# Altium schematic sheet Power.SchDoc(Power)
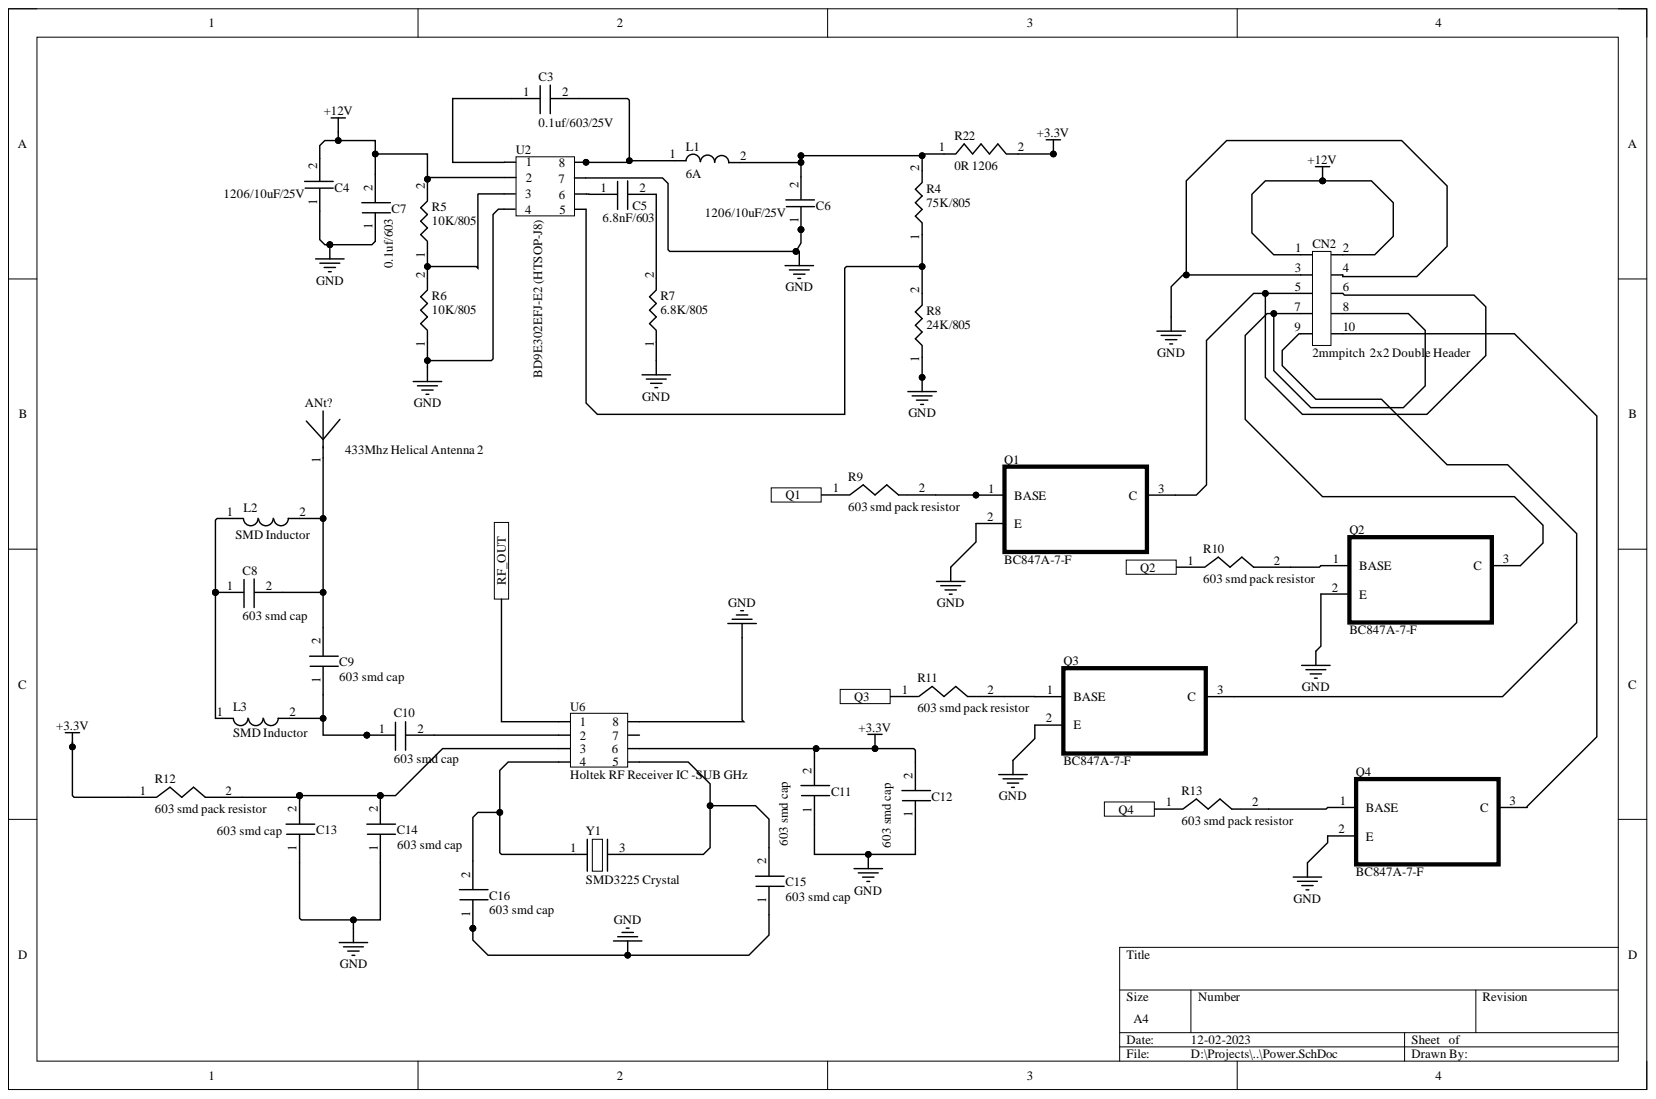
[diagram p0: Power.SchDoc]

## Parts
ANt?  433Mhz Helical Antenna 2  [433Mhz Antenna 2]
C3  0.1uf/603/25V  [603]
C4  1206/10uF/25V  [1206]
C5  6.8nF/603  [603]
C6  1206/10uF/25V  [1206]
C7  0.1uf/603  [603]
C8  603 smd cap  [603]
C9  603 smd cap  [603]
C10  603 smd cap  [603]
C11  603 smd cap  [603]
C12  603 smd cap  [603]
C13  603 smd cap  [603]
C14  603 smd cap  [603]
C15  603 smd cap  [603]
C16  603 smd cap  [603]
CN2  2mmpitch  2x2 Double Header  [DS1026-05]
L1  6A  [SMD Power Inductor PAD_1]
L2  SMD Inductor  [603]
L3  SMD Inductor  [603]
Q1  BC847A-7-F  [SOT95P240X110-3N]
Q2  BC847A-7-F  [SOT95P240X110-3N]
Q3  BC847A-7-F  [SOT95P240X110-3N]
Q4  BC847A-7-F  [SOT95P240X110-3N]
R4  75K/805  [805]
R5  10K/805  [805]
R6  10K/805  [805]
R7  6.8K/805  [805]
R8  24K/805  [805]
R9  603 smd pack resistor  [603]
R10  603 smd pack resistor  [603]
R11  603 smd pack resistor  [603]
R12  603 smd pack resistor  [603]
R13  603 smd pack resistor  [603]
R22  0R 1206  [1206]
U2  BD9E302EFJ-E2 (HTSOP-J8)  [8-Pin SOP-EP]
U6  Holtek RF Receiver IC -SUB GHz  [8-Pin SOP-EP]
Y1  SMD3225 Crystal  [SMD3225]

## Ports
Q1, Q2, Q3, Q4, RF_OUT

## Nets
+3.3V: C11.2 C12.2 R12.1 R22.2 U6.6
+12V: C4.2 C7.2 CN2.1 CN2.2 R5.2 U2.2
GND: C4.1 C6.1 C7.1 C11.1 C12.1 C13.1 C14.1 C15.1 C16.1 CN2.3 CN2.4 Q1.2 Q2.2 Q3.2 Q4.2 R6.1 R7.1 R8.1 U2.4 U2.7 U6.8
Q1: R9.1 ; port Q1
Q2: R10.1 ; port Q2
Q3: R11.1 ; port Q3
Q4: R13.1 ; port Q4
RF_OUT: U6.1 ; port RF_OUT
NetANt?_1: ANt?.1 C8.2 C9.2 L2.2
NetC3_1: C3.1 U2.1
NetC3_2: C3.2 L1.1 U2.8
NetC5_1: C5.1 U2.6
NetC5_2: C5.2 R7.2
NetC6_2: C6.2 L1.2 R4.2 R22.1
NetC8_1: C8.1 L2.1 L3.1
NetC9_1: C9.1 C10.1 L3.2
NetC10_2: C10.2 U6.2
NetC13_2: C13.2 C14.2 R12.2 U6.3
NetC15_2: C15.2 U6.5 Y1.3
NetC16_2: C16.2 U6.4 Y1.1
NetCN2_5: CN2.5 CN2.6 Q1.3
NetCN2_7: CN2.7 CN2.8 Q2.3
NetCN2_9: CN2.9 Q3.3
NetCN2_10: CN2.10 Q4.3
NetQ1_1: Q1.1 R9.2
NetQ2_1: Q2.1 R10.2
NetQ3_1: Q3.1 R11.2
NetQ4_1: Q4.1 R13.2
NetR4_1: R4.1 R8.2 U2.5
NetR5_1: R5.1 R6.2 U2.3

Not connected: U6 7

## Library data of the parts
C3 C5 C7 = 603 SMD CAP: Component Kind: Standard; Library Name: Custom1.IntLib
C4 C6 = 1206 NON-POL CAP: Component Kind: Standard; Library Name: Custom1.IntLib
CN2 = DS1026-05: Component Kind: Standard; Library Name: Custom1.IntLib
L1 = SMD Power Inductor 6A: Component Kind: Standard; Library Name: Custom1.IntLib
L2 L3 = 603 Inductor: Component Kind: Standard; Library Name: Custom1.IntLib
Q1-Q4 = BC847A-7-F: Bipolar (BJT) Transistor NPN 45V 100mA 300MHz 300mW Surface Mount SOT-23-3; MF: Diodes Inc.; Availability: Good; Check_prices: https://www.snapeda.com/parts/BC847A-7-F/Diodes%20Inc./view-part/484082/?ref=eda; Component Kind: Standard; Library Name: BC847A-7-F.IntLib; MP: BC847A-7-F; Package: SOT-23-3 Diodes Inc.; Price: None; Purchase-URL: https://pricing.snapeda.com/search/part/BC847A-7-F/?ref=eda; SnapEDA_Link: https://www.snapeda.com/parts/BC847A-7-F/Diodes%20Inc./view-part/484082/?ref=snap
R4-R8 = 805 Resistor: Component Kind: Standard; Library Name: Custom1.IntLib
R9-R13 = 603 Resistor: Component Kind: Standard; Library Name: Custom1.IntLib
R22 = 1206 Resistor: Component Kind: Standard; Library Name: Custom1.IntLib
U2 = BD9E302EFJ: Component Kind: Standard; Library Name: Custom1.IntLib
U6 = BC2302A: Component Kind: Standard; Library Name: Custom1.IntLib
Y1 = 3225 Crystal: Component Kind: Standard; Library Name: Custom1.IntLib
ANt? = 433MHz Helical Antenna 2: Component Kind: Standard; Library Name: Custom1.IntLib
C8-C16 = 603 SMD CAP: Component Kind: Standard; Library Name: Custom1.IntLib
